# Altium schematic sheet RF.SchDoc
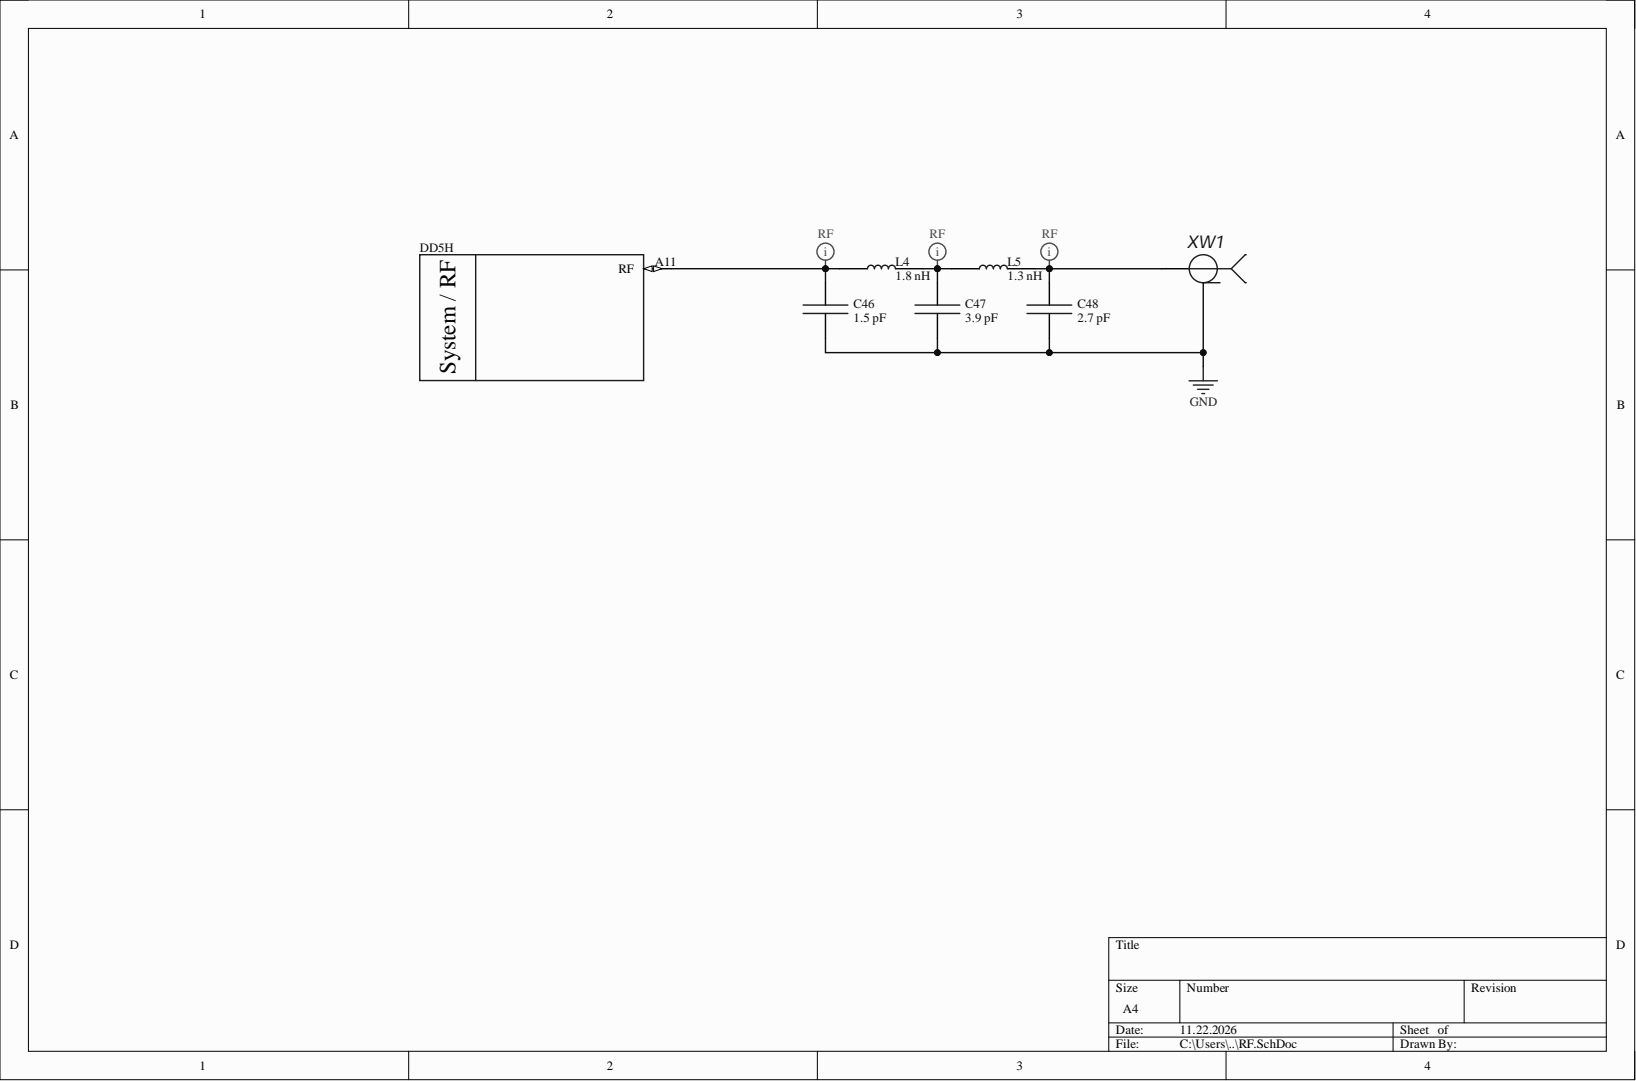
[diagram p0: RF.SchDoc]

## Parts
C46  1.5 pF  [C0402]
C47  3.9 pF  [C0402]
C48  2.7 pF  [C0402]
DD5  STM32WBA65PII6  [UFBGA-121_6x6_P0.5]  (value not drawn)  (part H)
L4  1.8 nH  [IND0402]
L5  1.3 nH  [IND0402]
XW1  U.FL-R-SMT-1(60)  [UFL_R_SMT_1]  (value not drawn)

## Nets
GND: C46.2 C47.2 C48.2 XW1.2
NetC46_1: C46.1 DD5.A11(RF) L4.1
NetC47_1: C47.1 L4.2 L5.1
NetC48_1: C48.1 L5.2 XW1.1

## Library data of the parts
C46 = C0402 NP0 1.5 pF ±0.25 pF 50 V: MLCC 0402, NP0, 1.5 pF, ±0.25 pF, 50 V; Database Table Name: C_Capacitor; ID: C_001117; Capacitance: 1.5 pF; Component Kind: Standard; ComponentLink1Description: Reference MPN: GRM1555C1H1R5CA01D; ComponentLink1URL: https://www.digikey.com/en/products/detail/murata-electronics/GRM1555C1H1R5CA01D/3481886; Library Name: Database_Libs1.DbLib; Mounting Technology: SMD; Package: C0402; Part Number: C0402 NP0 1.5 pF ±0.25 pF 50 V; Simulation: ±0.25 pF
C47 = C0402 NP0 3.9 pF ±0.25 pF 50 V: MLCC 0402, NP0, 3.9 pF, ±0.25 pF, 50 V; Database Table Name: C_Capacitor; ID: C_001118; Capacitance: 3.9 pF; Component Kind: Standard; ComponentLink1Description: Reference MPN: GRM1555C1H3R9CA01D; ComponentLink1URL: https://www.digikey.com/en/products/detail/murata-electronics/GRM1555C1H3R9CA01D/3693851; Library Name: Database_Libs1.DbLib; Mounting Technology: SMD; Package: C0402; Part Number: C0402 NP0 3.9 pF ±0.25 pF 50 V; Simulation: ±0.25 pF
C48 = C0402 NP0 2.7 pF ±0.25 pF 50 V: MLCC 0402, NP0, 2.7 pF, ±0.25 pF, 50 V; Database Table Name: C_Capacitor; ID: C_001119; Capacitance: 2.7 pF; Component Kind: Standard; ComponentLink1Description: Reference MPN: GRM1555C1H2R7CA01D; ComponentLink1URL: https://www.digikey.com/en/products/detail/murata-electronics/GRM1555C1H2R7CA01D/3721291; Library Name: Database_Libs1.DbLib; Mounting Technology: SMD; Package: C0402; Part Number: C0402 NP0 2.7 pF ±0.25 pF 50 V; Simulation: ±0.25 pF
DD5 = STM32WBA65PII6: IC, WIRELESS MCU, STM32WBA65PII6, CORTEX-M33, FPU, 100MHz, FLASH 2MB, RAM 512KB, BLE/IEEE 802.15.4, UFBGA121, 121P, P0.5mm, SMT; Manufacturer: STMicroelectronics; Architecture: 32-bit Armv8-M; Core: Arm Cortex-M33 with FPU; Database Table Name: IC_MCU; GPIO Count: 86; ID: ICMCU_000007; Supply Voltage: VDD 1.71-3.6 V; see supply-domain conditions; Component Kind: Standard; ComponentLink1Description: ST datasheet DS14736 Rev 3; ComponentLink1URL: https://www.st.com/resource/en/datasheet/stm32wba65pi.pdf; Flash: 2 MB; Library Name: Database_Libs1.DbLib; Manufacturer Part Number: STM32WBA65PII6; Max Frequency: 100 MHz; Mounting Technology: SMT; Package: UFBGA121 6x6 mm, pitch 0.5 mm; Part Number: STM32WBA65PII6; RAM: 512 KB
L4 = LQG15HS1N8S02D: INDUCTOR, RF, LQG15HS1N8S02D, 1.8 nH, ±0.3 nH, 950 mA, DCR 100 mOhm max, 0402; Manufacturer: Murata; Database Table Name: L_Inductor; ID: L_000003; Inductor Type: Multilayer RF; Rated Current: 950 mA; SRF: 6 GHz; Tolerance: ±0.3 nH; Component Kind: Standard; ComponentLink1Description: Product specifications; ComponentLink1URL: https://www.digikey.com/en/products/detail/murata-electronics/LQG15HS1N8S02D/662862; DCR: 100 mOhm max; Inductance: 1.8 nH; Library Name: Database_Libs1.DbLib; Manufacturer Part Number: LQG15HS1N8S02D; Mounting Technology: SMD; Package: 0402; Part Number: LQG15HS1N8S02D
L5 = LQG15HS1N3S02D: INDUCTOR, RF, LQG15HS1N3S02D, 1.3 nH, ±0.3 nH, 1 A, DCR 70 mOhm max, 0402; Manufacturer: Murata; Database Table Name: L_Inductor; ID: L_000004; Inductor Type: Multilayer RF; Rated Current: 1 A; SRF: 6 GHz; Tolerance: ±0.3 nH; Component Kind: Standard; ComponentLink1Description: Product specifications; ComponentLink1URL: https://www.digikey.com/en/products/detail/murata-electronics/LQG15HS1N3S02D/2594217; DCR: 70 mOhm max; Inductance: 1.3 nH; Library Name: Database_Libs1.DbLib; Manufacturer Part Number: LQG15HS1N3S02D; Mounting Technology: SMD; Package: 0402; Part Number: LQG15HS1N3S02D
XW1 = U.FL-R-SMT-1: CONN, RF COAXIAL, U.FL-R-SMT-1(60), 50 Ohm, VERTICAL, SMD; Manufacturer: Hirose Electric; Database Table Name: X_Connector; Gender: Receptacle; ID: X_000010; Component Kind: Standard; ComponentLink1Description: Manufacturer catalog; ComponentLink1URL: https://www.hirose.com/en/product/document?documentid=ed_U.FL_CAT&documenttype=Catalog&lang=en&series=U.FL; Connector Type: RF coaxial, U.FL; Library Name: Database_Libs1.DbLib; Manufacturer Part Number: U.FL-R-SMT-1(60); Mounting Technology: SMD; Package: U.FL, vertical; Part Number: U.FL-R-SMT-1
